# Altium schematic sheet 6.SchDoc(6)
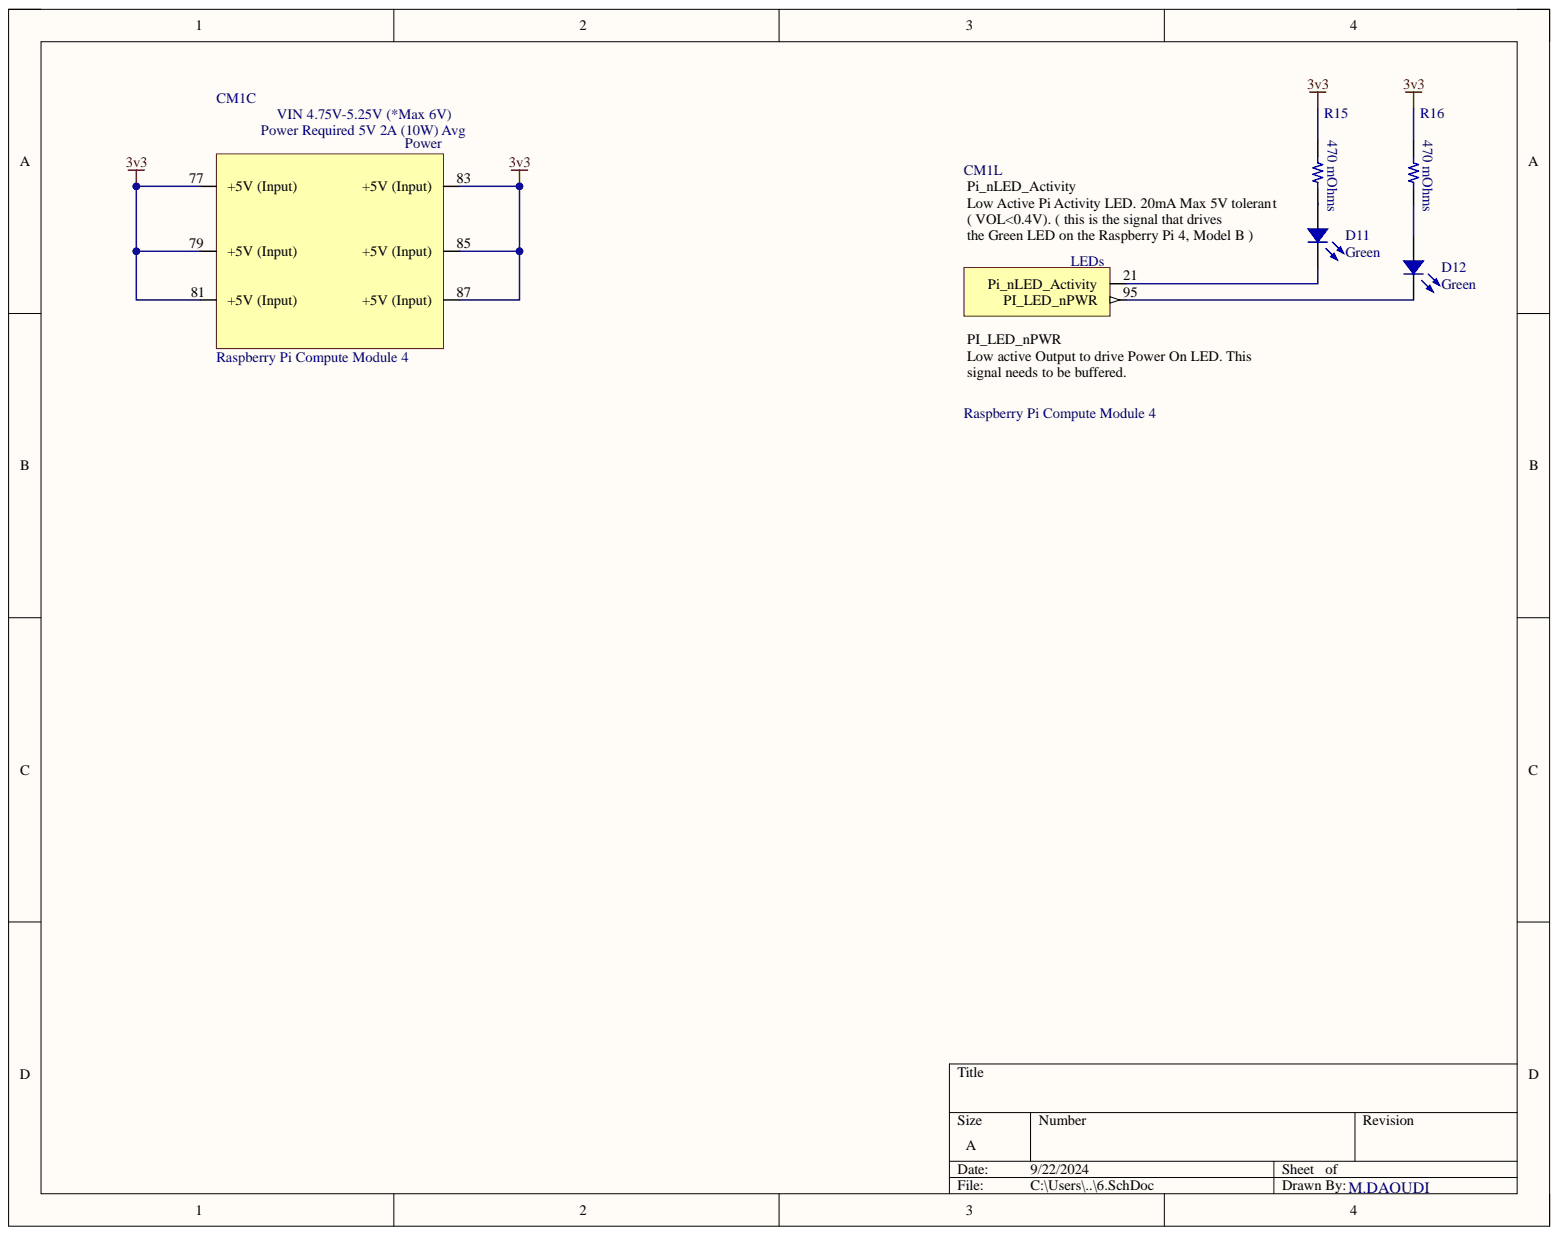
[diagram p0: 6.SchDoc]

## Parts
CM1  Raspberry Pi Compute Module 4  [CM4-F40C-100DS-0.4v_1.5mm_0mm]  (no BOM)  (parts C,L)
D11  Green  [LED 1206_3216 GREEN]
D12  Green  [LED 1206_3216 GREEN]
R15  470 mOhms  [RES 0402_1005]
R16  470 mOhms  [RES 0402_1005]

## Nets
3v3: CM1.77(+5V_(Input)) CM1.79(+5V_(Input)) CM1.81(+5V_(Input)) CM1.83(+5V_(Input)) CM1.85(+5V_(Input)) CM1.87(+5V_(Input)) R15.2 R16.2
NetCM1_21: CM1.21 D11.2
NetCM1_95: CM1.95 D12.2
NetD11_1: D11.1 R15.1
NetD12_1: D12.1 R16.1

## Library data of the parts
CM1 = CM4: Raspberry Pi Compute Module 4 by Amornthep Phunsin; Library Name: CM4.SchLib
D11 D12 = 597-6322-107F: LED GREEN 1206 SMD; Manufacturer: Dialight; Current - Test: 20mA; Database Table Name: LED - Indication; Lens Size: 1.20mm Dia; Lens Style: Round with Domed Top; Millicandela Rating: 1278.5mcd; Viewing Angle: 30°; Voltage - Forward (Vf) (Typ): 3.2V; Wavelength - Dominant: 525nm; Color: Green; Component Kind: Standard; ComponentLink1Description: Datasheet; ComponentLink1URL: https://s3-us-west-2.amazonaws.com/catsy.557/C18571.pdf; ComponentLink2Description: DigiKey Link; ComponentLink2URL: https://www.digikey.com/en/products/detail/dialight/597-6322-107F/16995758; Device Package: 1206 (3216 Metric); Height (Max): 1.73mm; LastUpdated: 6/3/2024 7:59:09 PM; Library Name: Celestial Altium Library - altium_library.DbLib; Lifecycle Status: Active; Minimum Order: 1; Mounting Type: Surface Mount; Package / Case: 1206 (3216 Metric); Packaging: TapeAndReel; Part Number: 597-6322-107F; Part Status: Active; PartId: 1292; Price: 0.17; Series: -; Size / Dimension: 3.20mm L x 1.60mm W; Supplier 1: DigiKey; Supplier Device Package: 1206; Supplier Part Number 1: 350-597-6322-107FCT-ND; Value: Green
R15 R16 = 73L1R47J: RES 0.47 OHM 5% 1/10W 0402; Manufacturer: CTS Resistor Products; Database Table Name: Resistors - Surface Mount - 0402; Power (Watts): 0.1W, 1/10W; Temperature Coefficient: ±300ppm/°C; Component Kind: Standard; ComponentLink1Description: Datasheet; ComponentLink1URL: https://www.ctscorp.com/wp-content/uploads/2015/11/008-0337-0.pdf; ComponentLink2Description: DigiKey Link; ComponentLink2URL: https://www.digikey.com/en/products/detail/cts-resistor-products/73L1R47J/1543472; Composition: Thick Film; Device Package: 0402 (1005 Metric); Features: Current Sense; Height - Seated (Max): 0.016" (0.40mm); LastUpdated: 2/9/2024 8:29:22 PM; Library Name: Celestial Altium Library - altium_library.DbLib; Lifecycle Status: Active; Minimum Order: 1; Number of Terminations: 2; Operating Temperature: -55°C ~ 125°C; Package / Case: 0402 (1005 Metric); Packaging: TapeAndReel; Part Number: 73L1R47J; Part Status: Active; PartId: 20067; Price: 0.01; Resistance: 470 mOhms; Series: 73L1; Size / Dimension: 0.039" L x 0.020" W (1.00mm x 0.50mm); Supplier 1: DigiKey; Supplier Device Package: 0402; Supplier Part Number 1: 73L1R47JCT-ND; Tolerance: ±5%; Value: 470 mOhms
